# Altium schematic sheet Power.SchDoc(Power)
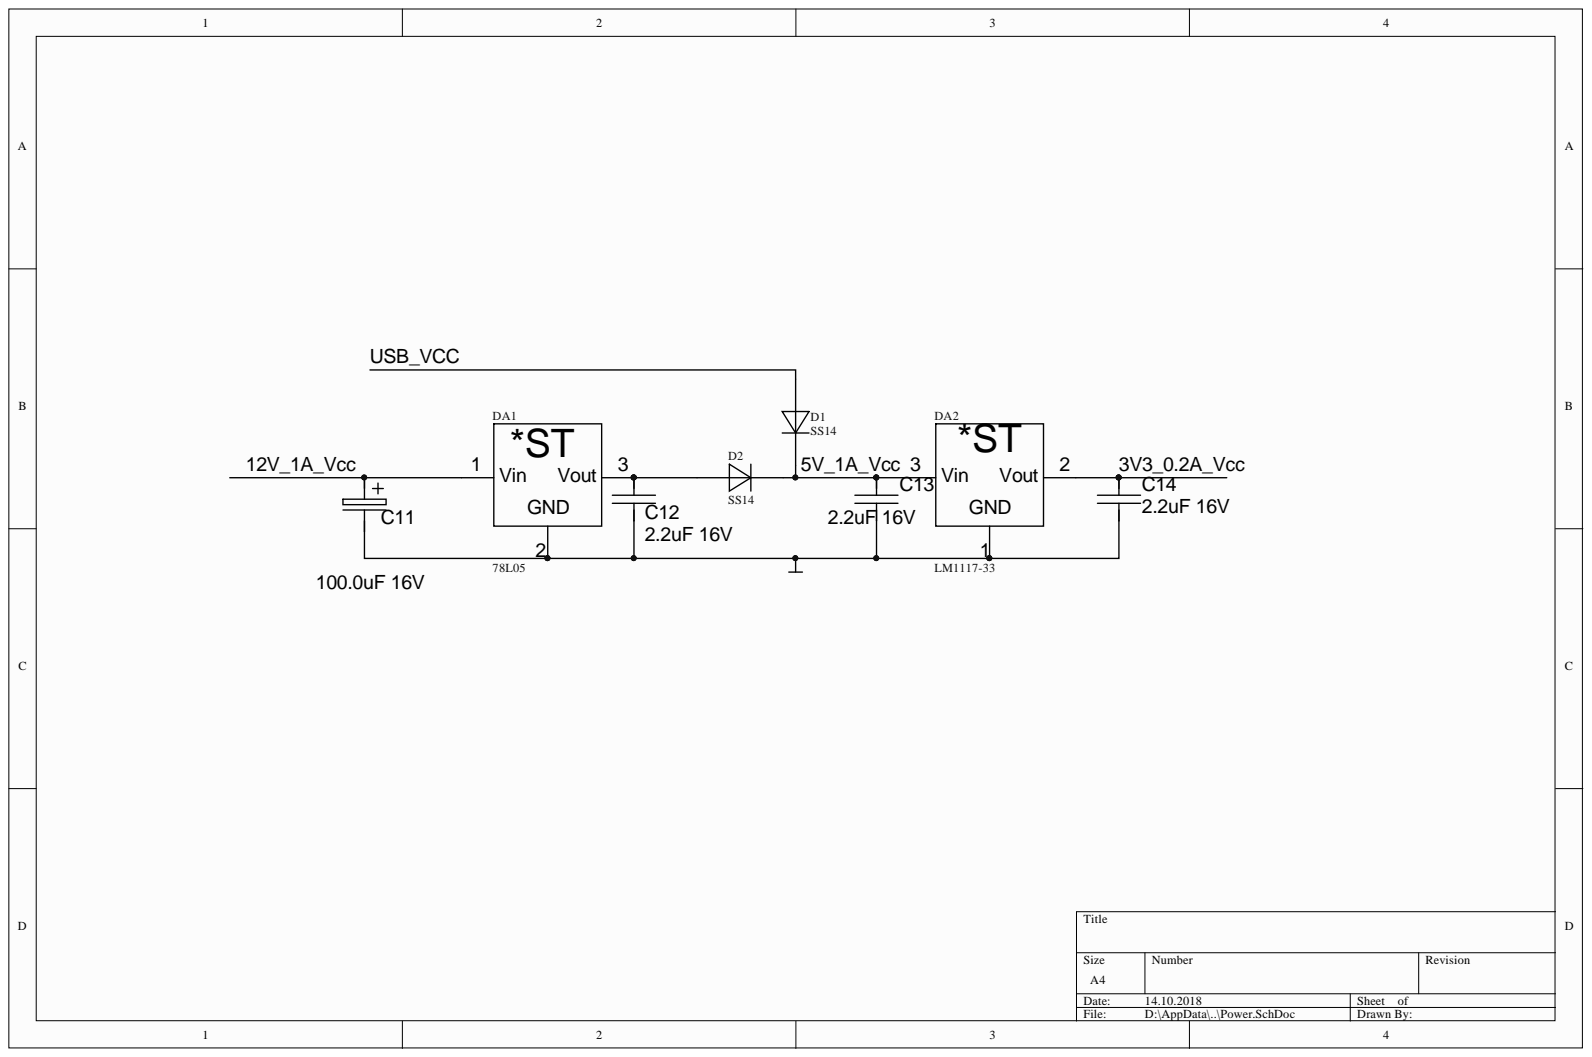
[diagram p0: Power.SchDoc]

## Parts
C11  100.0uF 16V  [CAP_P_8mm_3.5mm]
C12  2.2uF 16V  [0805_CAP]
C13  2.2uF 16V  [0805_CAP]
C14  2.2uF 16V  [0805_CAP]
D1  SS14  [SMA_diode]
D2  SS14  [SMA_diode]
DA1  78L05  [to-220ab]
DA2  LM1117-33  [dpack]

## Nets
GND: C11.2 C12.1 C13.1 C14.1 DA1.2 DA2.1
3V3_0.2A_Vcc: C14.2 DA2.2
5V_1A_Vcc: C13.2 D1.2 D2.2 DA2.3
12V_1A_Vcc: C11.1 DA1.1
USB_VCC: D1.1
NetC12_2: C12.2 D2.1 DA1.3

## Library data of the parts
C11 = Cap_p: Group: Passive; Value: 100.0uF 16V; Component Kind: Standard; Library Name: Integrated_Library_tikhovikt.IntLib
C12-C14 = Cap_np: Value: 2.2uF 16V; Component Kind: Standard; Library Name: Integrated_Library_tikhovikt.IntLib
D1 D2 = Diode: Value: SS14; Component Kind: Standard; Library Name: Integrated_Library_tikhovikt.IntLib
DA2 = LM1117: Value: LM1117-33; Component Kind: Standard; Library Name: Integrated_Library_tikhovikt.IntLib
DA1 = 78L05: Value: 78L05; Component Kind: Standard; Library Name: Integrated_Library_tikhovikt.IntLib
